# Altium schematic sheet 4G_Relay_X2(3034GREX103).SchDoc
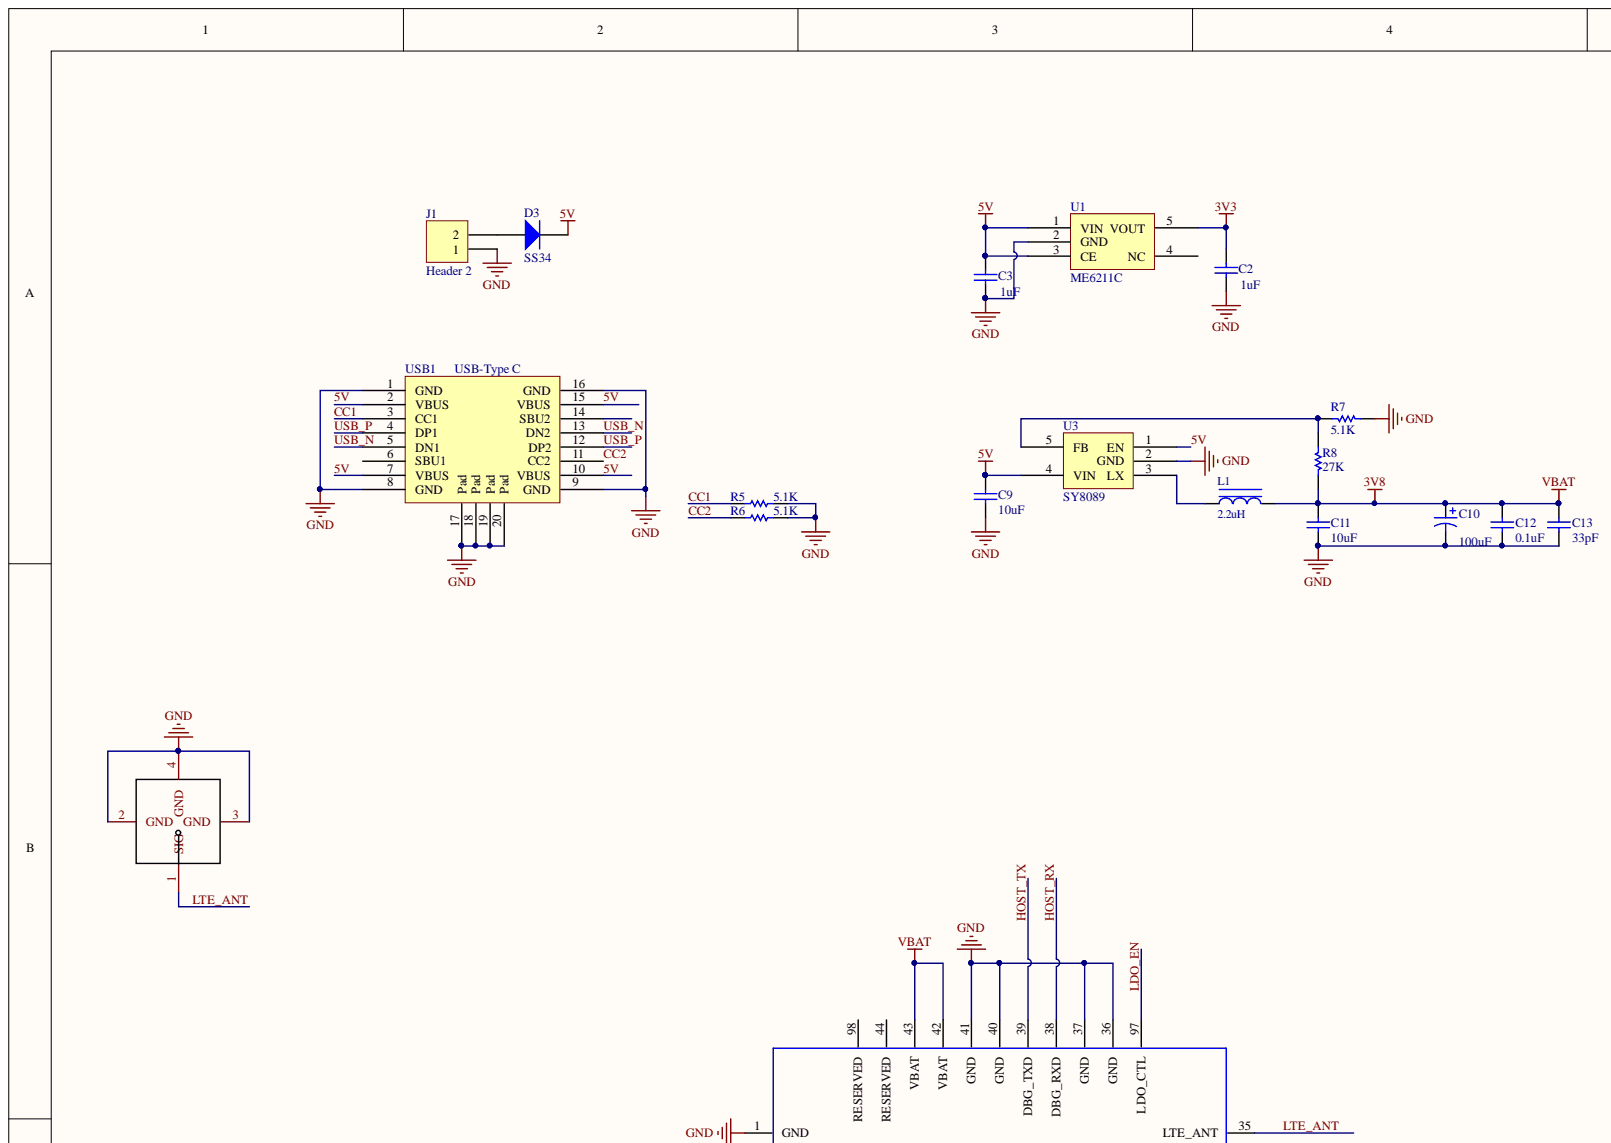
[diagram p0: 4G_Relay_X2(3034GREX103).SchDoc, top left]
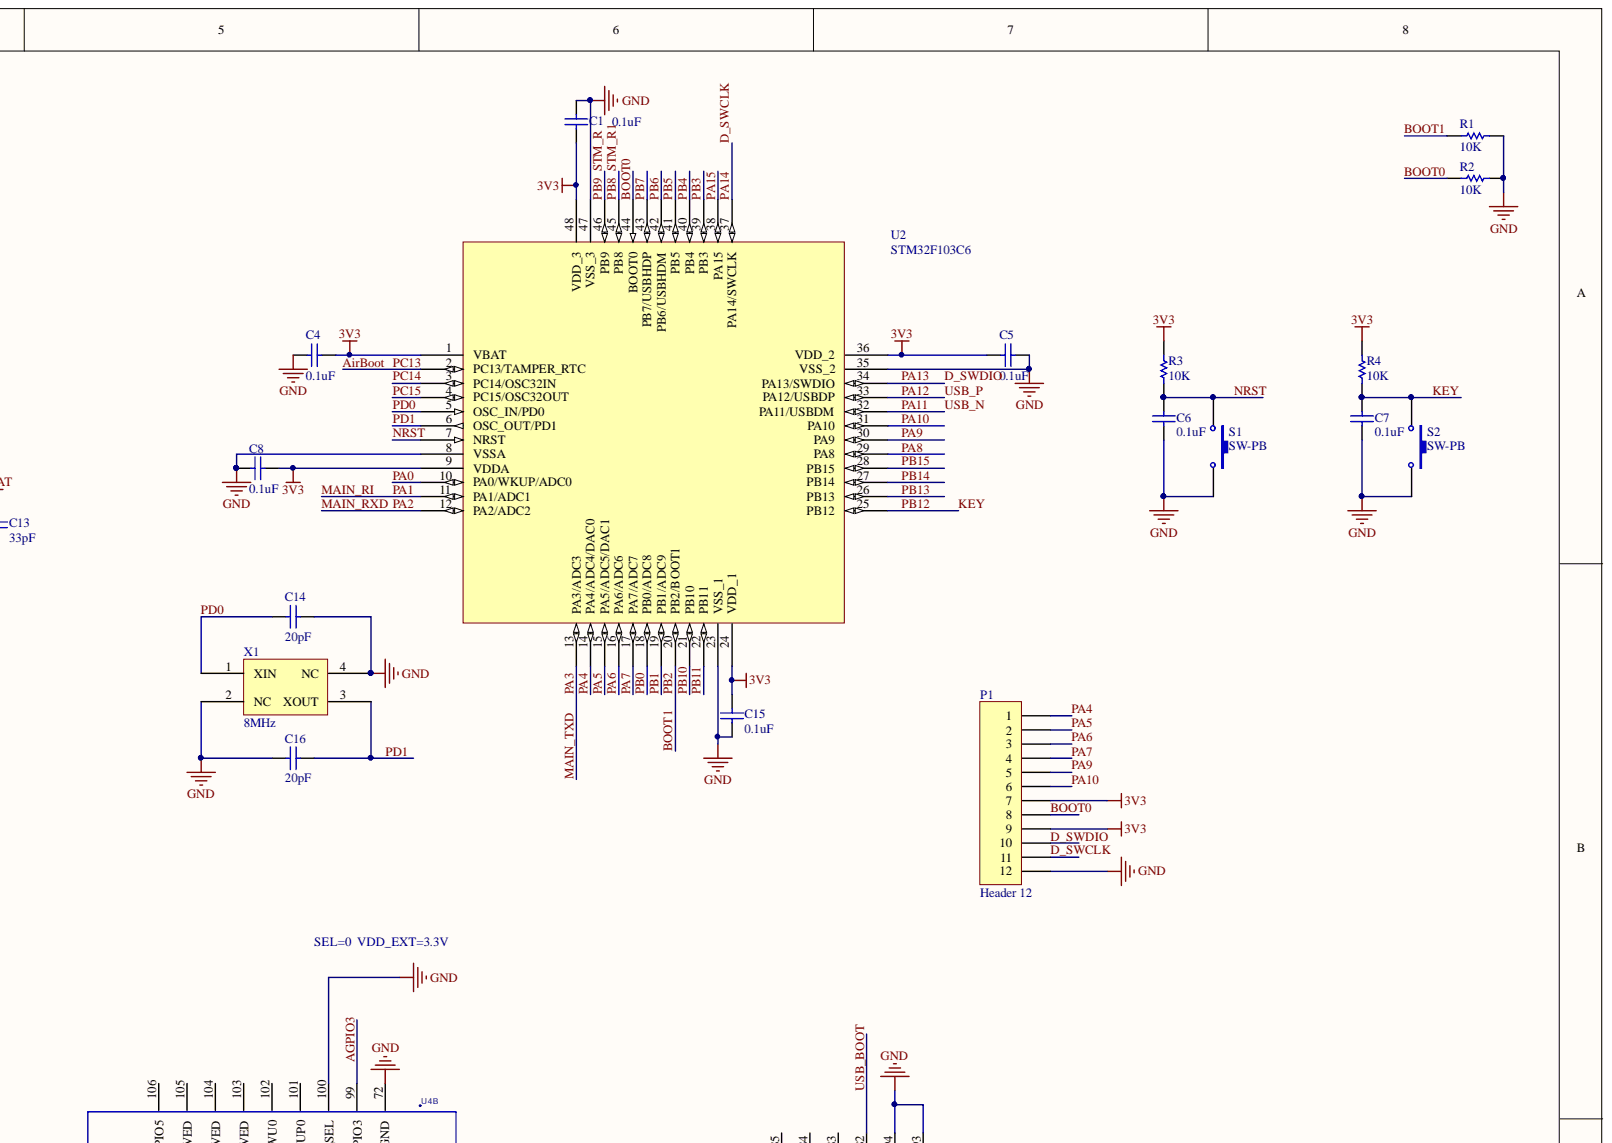
[diagram p1: 4G_Relay_X2(3034GREX103).SchDoc, top right]
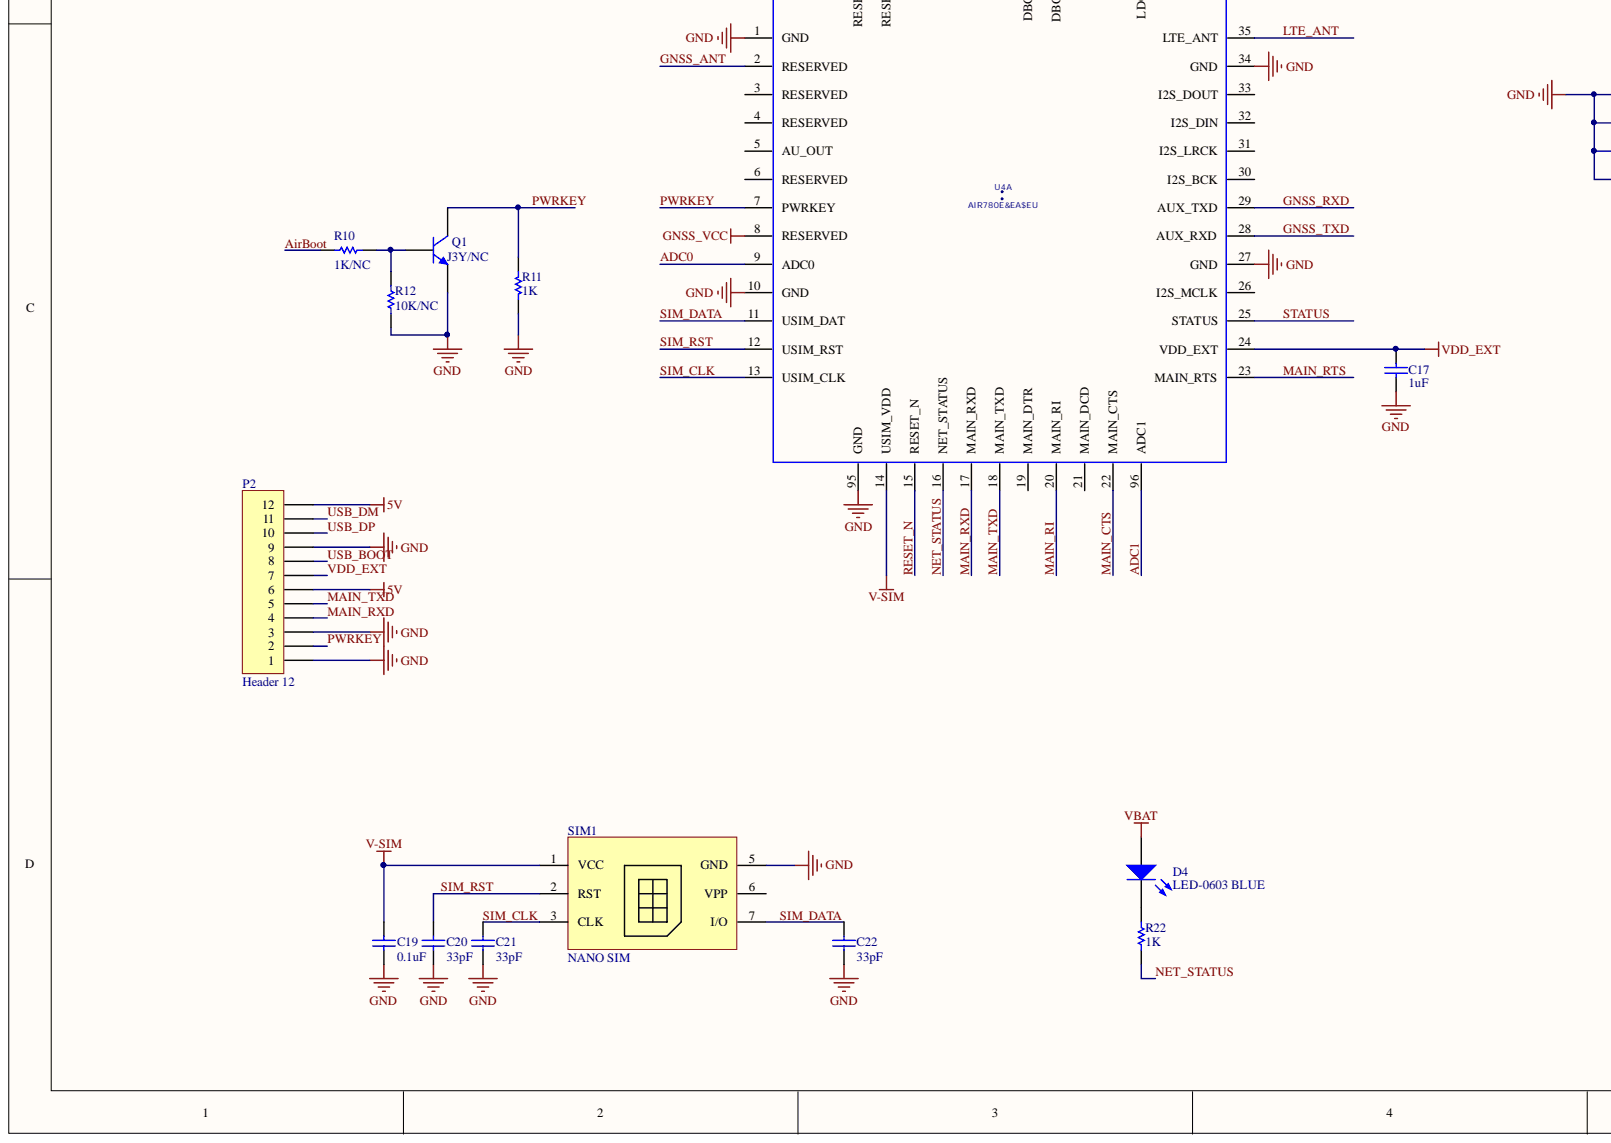
[diagram p2: 4G_Relay_X2(3034GREX103).SchDoc, bottom left]
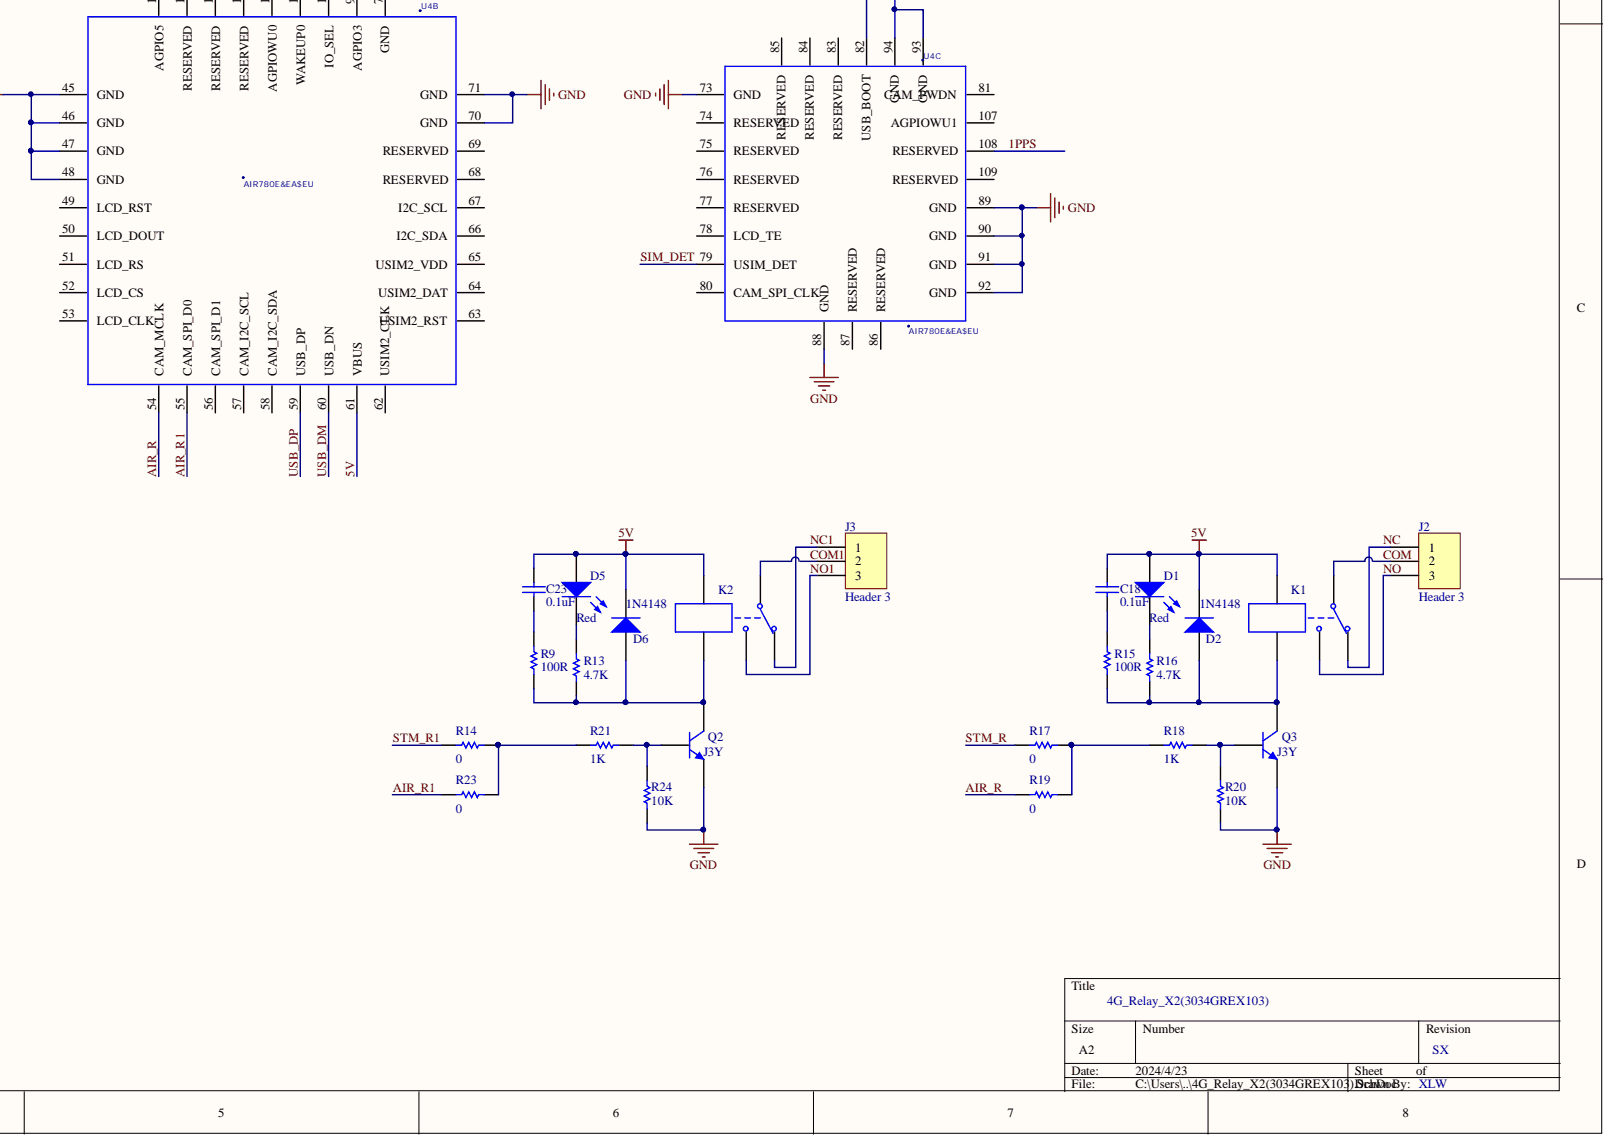
[diagram p3: 4G_Relay_X2(3034GREX103).SchDoc, bottom right]

## Parts
C1  0.1uF  [C0603]
C2  1uF  [C0603]
C3  1uF  [C0603]
C4  0.1uF  [C0603]
C5  0.1uF  [C0603]
C6  0.1uF  [C0603]
C7  0.1uF  [C0603]
C8  0.1uF  [C0603]
C9  10uF  [C0603]
C10  value 100uF  [CAP-SMD_L3.5-W2.8]  (hidden comment: Cap Pol1)
C11  10uF  [C0603]
C12  0.1uF  [C0603]
C13  33pF  [C0603]
C14  20pF  [C0603]
C15  0.1uF  [C0603]
C16  20pF  [C0603]
C17  1uF  [C0603]
C18  0.1uF  [C0603]
C19  0.1uF  [C0603]
C20  33pF  [C0603]
C21  33pF  [C0603]
C22  33pF  [C0603]
C23  0.1uF  [C0603]
D1  Red  [LED-0603]
D2  1N4148  [DIODE-1206]
D3  SS34  [SMA]
D4  LED-0603 BLUE  [LED-0603]
D5  Red  [LED-0603]
D6  1N4148  [DIODE-1206]
J1  Header 2  [CONN-TH_2P-P5.00_WJ128V-5.0-2P]
J2  Header 3  [CONN-TH_3P-P5.00_WJ128V-5.0-3P]
J3  Header 3  [CONN-TH_3P-P5.00_WJ128V-5.0-3P]
K1  Relay_T73  [RELAY-SRD(T73)]  (value not drawn)
K2  Relay_T73  [RELAY-SRD(T73)]  (value not drawn)
L1  2.2uH  [SIP_2]
P1  Header 12  [HDR1X12]
P2  Header 12  [HDR1X12]
Q1  J3Y/NC  [S8050-SOT23]
Q2  J3Y  [S8050-SOT23]
Q3  J3Y  [S8050-SOT23]
R1  10K  [R0603]
R2  10K  [R0603]
R3  10K  [R0603]
R4  10K  [R0603]
R5  5.1K  [R0603]
R6  5.1K  [R0603]
R7  5.1K  [R0603]
R8  27K  [R0603]
R9  100R  [R0603]
R10  1K/NC  [R0603]
R11  1K  [R0603]
R12  10K/NC  [R0603]
R13  4.7K  [R0603]
R14  0  [R0603]
R15  100R  [R0603]
R16  4.7K  [R0603]
R17  0  [R0603]
R18  1K  [R0603]
R19  0  [R0603]
R20  10K  [R0603]
R21  1K  [R0603]
R22  1K  [R0603]
R23  0  [R0603]
R24  10K  [R0603]
RF1  KH-IPEX-K501-29  [ANT-SMD_KH-IPEX-K501-29]  (value not drawn)
S1  SW-PB  [Key]
S2  SW-PB  [Key]
SIM1  NANO SIM  [SIM-SMD_SMN-305]
U1  ME6211C  [SOT23-5]
U2  STM32F103C6  [LQFP48]
U3  SY8089  [SOT23-5]
U4  AIR780E&EA$EU  [AIR780E]  (parts A,B,C)
USB1  USB-Type C  [USB-Type C SMD 16P]
X1  8MHz  [CRYSTAL_SMD3.2X2.5_FIRE]

## Nets
3V3: C1.1 C2.2 C4.1 C5.2 C8.2 C15.1 P1.7 P1.9 R3.1 R4.1 U1.5(VOUT) U2.1(VBAT) U2.9(VDDA) U2.24(VDD_1) U2.36(VDD_2) U2.48(VDD_3)
3V8: C10.1 C11.2 C12.2 C13.2 D4.1 L1.2 R8.2 U4.42(VBAT) U4.43(VBAT)
GND: C1.2 C2.1 C3.1 C4.2 C5.1 C6.2 C7.2 C8.1 C9.1 C10.2 C11.1 C12.1 C13.1 C14.2 C15.2 C16.1 C17.1 C19.1 C20.1 C21.1 C22.1 J1.1 P1.12 P2.1 P2.3 P2.9 Q1.2 Q2.2 Q3.2 R1.1 R2.1 R5.2 R6.2 R7.1 R11.2 R12.1 R20.1 R24.1 RF1.2(GND) RF1.3(GND) RF1.4(GND) S1.2 S2.2 SIM1.5(GND) U1.2(GND) U2.8(VSSA) U2.23(VSS_1) U2.35(VSS_2) U2.47(VSS_3) U3.2(GND) U4.1(GND) U4.10(GND) U4.27(GND) U4.34(GND) U4.36(GND) U4.37(GND) U4.40(GND) U4.41(GND) U4.45(GND) U4.46(GND) U4.47(GND) U4.48(GND) U4.70(GND) U4.71(GND) U4.72(GND) U4.73(GND) U4.88(GND) U4.89(GND) U4.90(GND) U4.91(GND) U4.92(GND) U4.93(GND) U4.94(GND) U4.95(GND) U4.100(IO_SEL) USB1.1(GND) USB1.8(GND) USB1.9(GND) USB1.16(GND) USB1.17(Pad) USB1.18(Pad) USB1.19(Pad) USB1.20(Pad) X1.2(NC) X1.4(NC)
GNSS_VCC: U4.8(RESERVED)
V-SIM: C19.2 SIM1.1(VCC) U4.14(USIM_VDD)
1PPS: U4.108(RESERVED)
5V: C3.2 C9.2 C18.2 C23.2 D1.1 D2.2 D3.2 D5.1 D6.2 K1.4 K2.4 P2.6 P2.12 U1.1(VIN) U1.3(CE) U3.1(EN) U3.4(VIN) U4.61(VBUS) USB1.2(VBUS) USB1.7(VBUS) USB1.10(VBUS) USB1.15(VBUS)
ADC0: U4.9(ADC0)
ADC1: U4.96(ADC1)
AGPIO3: U4.99(AGPIO3)
AIR_R: R19.2 U4.54(CAM_MCLK)
AIR_R1: R23.2 U4.55(CAM_SPI_D0)
AirBoot: R10.2 U2.2(PC13/TAMPER_RTC)
BOOT0: P1.8 R2.2 U2.44(BOOT0)
BOOT1: R1.2 U2.20(PB2/BOOT1)
CC1: R5.1 USB1.3(CC1)
CC2: R6.1 USB1.11(CC2)
COM: J2.2 K1.1
COM1: J3.2 K2.1
D_SWCLK: P1.11 U2.37(PA14/SWCLK)
D_SWDIO: P1.10 U2.34(PA13/SWDIO)
GNSS_ANT: U4.2(RESERVED)
GNSS_RXD: U4.29(AUX_TXD)
GNSS_TXD: U4.28(AUX_RXD)
HOST_RX: U4.38(DBG_RXD)
HOST_TX: U4.39(DBG_TXD)
KEY: C7.1 R4.2 S2.1 U2.25(PB12)
LDO_EN: U4.97(LDO_CTL)
LTE_ANT: RF1.1(SIG) U4.35(LTE_ANT)
MAIN_CTS: U4.22(MAIN_CTS)
MAIN_RI: U2.11(PA1/ADC1) U4.20(MAIN_RI)
MAIN_RTS: U4.23(MAIN_RTS)
MAIN_RXD: P2.4 U2.12(PA2/ADC2) U4.17(MAIN_RXD)
MAIN_TXD: P2.5 U2.13(PA3/ADC3) U4.18(MAIN_TXD)
NC: J2.1 K1.2
NC1: J3.1 K2.2
NET_STATUS: R22.1 U4.16(NET_STATUS)
NO: J2.3 K1.3
NO1: J3.3 K2.3
NRST: C6.1 R3.2 S1.1 U2.7(NRST)
PA0: U2.10(PA0/WKUP/ADC0)
PA4: P1.1 U2.14(PA4/ADC4/DAC0)
PA5: P1.2 U2.15(PA5/ADC5/DAC1)
PA6: P1.3 U2.16(PA6/ADC6)
PA7: P1.4 U2.17(PA7/ADC7)
PA8: U2.29(PA8)
PA9: P1.5 U2.30(PA9)
PA10: P1.6 U2.31(PA10)
PA11: U2.32(PA11/USBDM) USB1.5(DN1) USB1.13(DN2)
PA12: U2.33(PA12/USBDP) USB1.4(DP1) USB1.12(DP2)
PA15: U2.38(PA15)
PB0: U2.18(PB0/ADC8)
PB1: U2.19(PB1/ADC9)
PB3: U2.39(PB3)
PB4: U2.40(PB4)
PB5: U2.41(PB5)
PB6: U2.42(PB6/USBHDM)
PB7: U2.43(PB7/USBHDP)
PB8: R14.2 U2.45(PB8)
PB9: R17.2 U2.46(PB9)
PB10: U2.21(PB10)
PB11: U2.22(PB11)
PB13: U2.26(PB13)
PB14: U2.27(PB14)
PB15: U2.28(PB15)
PC14: U2.3(PC14/OSC32IN)
PC15: U2.4(PC15/OSC32OUT)
PD0: C14.1 U2.5(OSC_IN/PD0) X1.1(XIN)
PD1: C16.2 U2.6(OSC_OUT/PD1) X1.3(XOUT)
PWRKEY: P2.2 Q1.3 R11.1 U4.7(PWRKEY)
RESET_N: U4.15(RESET_N)
SIM_CLK: C21.2 SIM1.3(CLK) U4.13(USIM_CLK)
SIM_DATA: C22.2 SIM1.7(I/O) U4.11(USIM_DAT)
SIM_DET: U4.79(USIM_DET)
SIM_RST: C20.2 SIM1.2(RST) U4.12(USIM_RST)
STATUS: U4.25(STATUS)
USB_BOOT: P2.8 U4.82(USB_BOOT)
USB_DM: P2.11 U4.60(USB_DN)
USB_DP: P2.10 U4.59(USB_DP)
VDD_EXT: C17.2 P2.7 U4.24(VDD_EXT)
NetC18_1: C18.1 R15.2
NetC23_1: C23.1 R9.2
NetD1_2: D1.2 R16.2
NetD2_1: D2.1 K1.5 Q3.3 R15.1 R16.1
NetD3_1: D3.1 J1.2
NetD4_2: D4.2 R22.2
NetD5_2: D5.2 R13.2
NetD6_1: D6.1 K2.5 Q2.3 R9.1 R13.1
NetL1_1: L1.1 U3.3(LX)
NetQ1_1: Q1.1 R10.1 R12.2
NetQ2_1: Q2.1 R21.1 R24.2
NetQ3_1: Q3.1 R18.1 R20.2
NetR7_2: R7.2 R8.1 U3.5(FB)
NetR14_1: R14.1 R21.2 R23.1
NetR17_1: R17.1 R18.2 R19.1

Not connected: SIM1 6(VPP); U1 4(NC); U4 3(RESERVED) 4(RESERVED) 5(AU_OUT) 6(RESERVED) 19(MAIN_DTR) 21(MAIN_DCD) 26(I2S_MCLK) 30(I2S_BCK) 31(I2S_LRCK) 32(I2S_DIN) 33(I2S_DOUT) 44(RESERVED) 49(LCD_RST) 50(LCD_DOUT) 51(LCD_RS) 52(LCD_CS) 53(LCD_CLK) 56(CAM_SPI_D1) 57(CAM_I2C_SCL) 58(CAM_I2C_SDA) 62(USIM2_CLK) 63(USIM2_RST) 64(USIM2_DAT) 65(USIM2_VDD) 66(I2C_SDA) 67(I2C_SCL) 68(RESERVED) 69(RESERVED) 74(RESERVED) 75(RESERVED) 76(RESERVED) 77(RESERVED) 78(LCD_TE) 80(CAM_SPI_CLK) 81(CAM_PWDN) 83(RESERVED) 84(RESERVED) 85(RESERVED) 86(RESERVED) 87(RESERVED) 98(RESERVED) 101(WAKEUP0) 102(AGPIOWU0) 103(RESERVED) 104(RESERVED) 105(RESERVED) 106(AGPIO5) 107(AGPIOWU1) 109(RESERVED); USB1 6(SBU1) 14(SBU2)

## Library data of the parts
C1-C9 C11-C13 C15 C17-C23 = Cap: Capacitor; Component Kind: Standard; LatestRevisionDate: 17-Jul-2002; LatestRevisionNote: Re-released for DXP Platform.; PackageReference: RAD-0.3; Published: 8-Jun-2000; Signal Integrity: Cap; Simulation: CAP; Publisher: Altium Limited
  C1 C4-C8 C15 C18 C23: Library Name: Add common lib.SchLib
  C2 C3: Library Name: Miscellaneous Devices.IntLib; Value: 1uF
  C9 C11-C13 C17 C19-C22: Library Name: CherryPi-PC-F133(303F133D1S1).SchLib
C10 = Cap Pol1: Polarized Capacitor (Radial); Component Kind: Standard; LatestRevisionDate: 17-Jul-2002; LatestRevisionNote: Re-released for DXP Platform.; Library Name: Miscellaneous Devices.IntLib; PackageReference: RB7.6-15; Published: 8-Jun-2000; Signal Integrity: Cap Pol; Simulation: CAP; Publisher: Altium Limited
C14 C16 = Cap: Capacitor; Value: 100pF; Component Kind: Standard; LatestRevisionDate: 17-Jul-2002; LatestRevisionNote: Re-released for DXP Platform.; Library Name: Miscellaneous Devices.IntLib; PackageReference: RAD-0.3; Published: 8-Jun-2000; Signal Integrity: Cap; Simulation: CAP; Publisher: Altium Limited
D1 D5 = LED-0805: Typical INFRARED GaAs LED; Component Kind: Standard; LatestRevisionDate: 08-Jul-2005; LatestRevisionNote: Mech Layer 1 removed.; Library Name: LC-common.SchLib; PackageReference: LED-0; Published: 8-Jun-2000; Simulation: LED0; Publisher: Altium Limited
D2 D3 D6 = 1N4148-Diode: Default Diode; Code_JEDEC: DO-214-AB; Component Kind: Standard; LatestRevisionDate: 17-Jul-2002; LatestRevisionNote: Re-released for DXP Platform.; Library Name: LC-common.SchLib; PackageReference: SMC; PackageVersion: Sep-1996; Published: 8-Jun-2000; Signal Integrity: Diode; Simulation: DIODE; Publisher: Altium Limited
D4 = LED-0603: Typical INFRARED GaAs LED; Component Kind: Standard; LatestRevisionDate: 08-Jul-2005; LatestRevisionNote: Mech Layer 1 removed.; Library Name: LC-common.SchLib; PackageReference: LED-0; Published: 8-Jun-2000; Simulation: LED0; Publisher: Altium Limited
J1 = Header 2: Header, 2-Pin; Component Kind: Standard; LatestRevisionDate: 17-Jul-2002; LatestRevisionNote: Re-released for DXP Platform.; Library Name: Miscellaneous Connectors.IntLib; Signal Integrity: Connector; Publisher: Altium Limited
J2 J3 = Header 3: Header, 3-Pin; Component Kind: Standard; LatestRevisionDate: 17-Jul-2002; LatestRevisionNote: Re-released for DXP Platform.; Library Name: Miscellaneous Connectors.IntLib; Publisher: Altium Limited
K1 K2 = Relay_T73: Single-Pole Dual-Throw Relay; Component Kind: Standard; LatestRevisionDate: 17-Jul-2002; LatestRevisionNote: Re-released for DXP Platform.; Library Name: LC-common.SchLib; PackageReference: MODULE5B; Published: 24-Mar-1999; Simulation: SPDTRELAY; Publisher: Altium Limited
P1 P2 = Header 12: Header, 12-Pin; Component Kind: Standard; LatestRevisionDate: 17-Jul-2002; LatestRevisionNote: Re-released for DXP Platform.; Library Name: Miscellaneous Connectors.IntLib; Signal Integrity: Connector; Publisher: Altium Limited
Q1-Q3 = S8050NPN: NPN Bipolar Transistor; Code_JEDEC: TO-226-AA; Component Kind: Standard; LatestRevisionDate: 17-Jul-2002; LatestRevisionNote: Re-released for DXP Platform.; Library Name: LC-common.SchLib; PackageReference: TO-226; Published: 8-Jun-2000; Signal Integrity: NPN; Simulation: NPN; Publisher: Altium Limited
R1-R24 = Res1: Resistor; Component Kind: Standard; LatestRevisionDate: 17-Jul-2002; LatestRevisionNote: Re-released for DXP Platform.; Library Name: LC-common.SchLib; PackageReference: AXIAL-0.3; Published: 8-Jun-2000; Signal Integrity: Res; Simulation: RESISTOR; Publisher: Altium Limited
RF1 = KH-IPEX-K501-29: Add into BOM: yes; Frequency-Max: 3GHz; Interface Diameter: 2mm; Interface Length/Height: 1.25mm; Number of Ports: 1; RF Series: IPEX; Component Kind: Standard; Convert to PCB: yes; Datasheet: https://atta.szlcsc.com/upload/public/pdf/source/20220620/3534C43453AFDE5147CC743E04DB9A56.pdf; Device: KH-IPEX-K501-29; JLCPCB Part Class: Extended Part; Manufacturer Part: KH-IPEX-K501-29; Name: KH-IPEX-K501-29; PCB3D: SMD_L2.6-W2.6-H1.3-LS3.1; Style: -; Supplier: LCSC; Supplier Footprint: SMD; Supplier Part: C411563; Symbol: KH-IPEX-K501-29
S1 S2 = SW-PB: Switch; Component Kind: Standard; LatestRevisionDate: 17-Jul-2002; LatestRevisionNote: Re-released for DXP Platform.; Library Name: 4G_Relay_X1(3034GREX101).SchLib; PackageReference: SPST-2; Published: 24-Mar-1999; Publisher: Altium Limited
SIM1 = microsim: Component Kind: Standard; Library Name: SIM800C.SchLib
U2 = CH32F103C: ARM M3 MCU with USB device & USB host & ADC & 12bit DAC & Touch Key & CAN; Component Kind: Standard; Library Name: CHXXX.schlib
U3 = SY8008: Component Kind: Standard; Library Name: CherryPi-PC-F133(303F133D1S1).SchLib
USB1 = USB-Type C SMD 16P: Component Kind: Standard; Description: USB-Type C SMD 16P; Library Name: K210_Dock.SchLib
X1 = XTAL: Component Kind: Standard; Library Name: DapLink_Lite(303DAPLIN04).SCHLIB
L1 = 2.2uH: Component Kind: Standard
U1 = ME6211C: Component Kind: Standard; Library Name: CANABLE(303CANABLE1).SCHLIB
U4 = AIR780E&EA$EU: Component Kind: Standard; PCB DECAL: AIR780E
